# Altium schematic sheet DIGITAL_IO.SchDoc
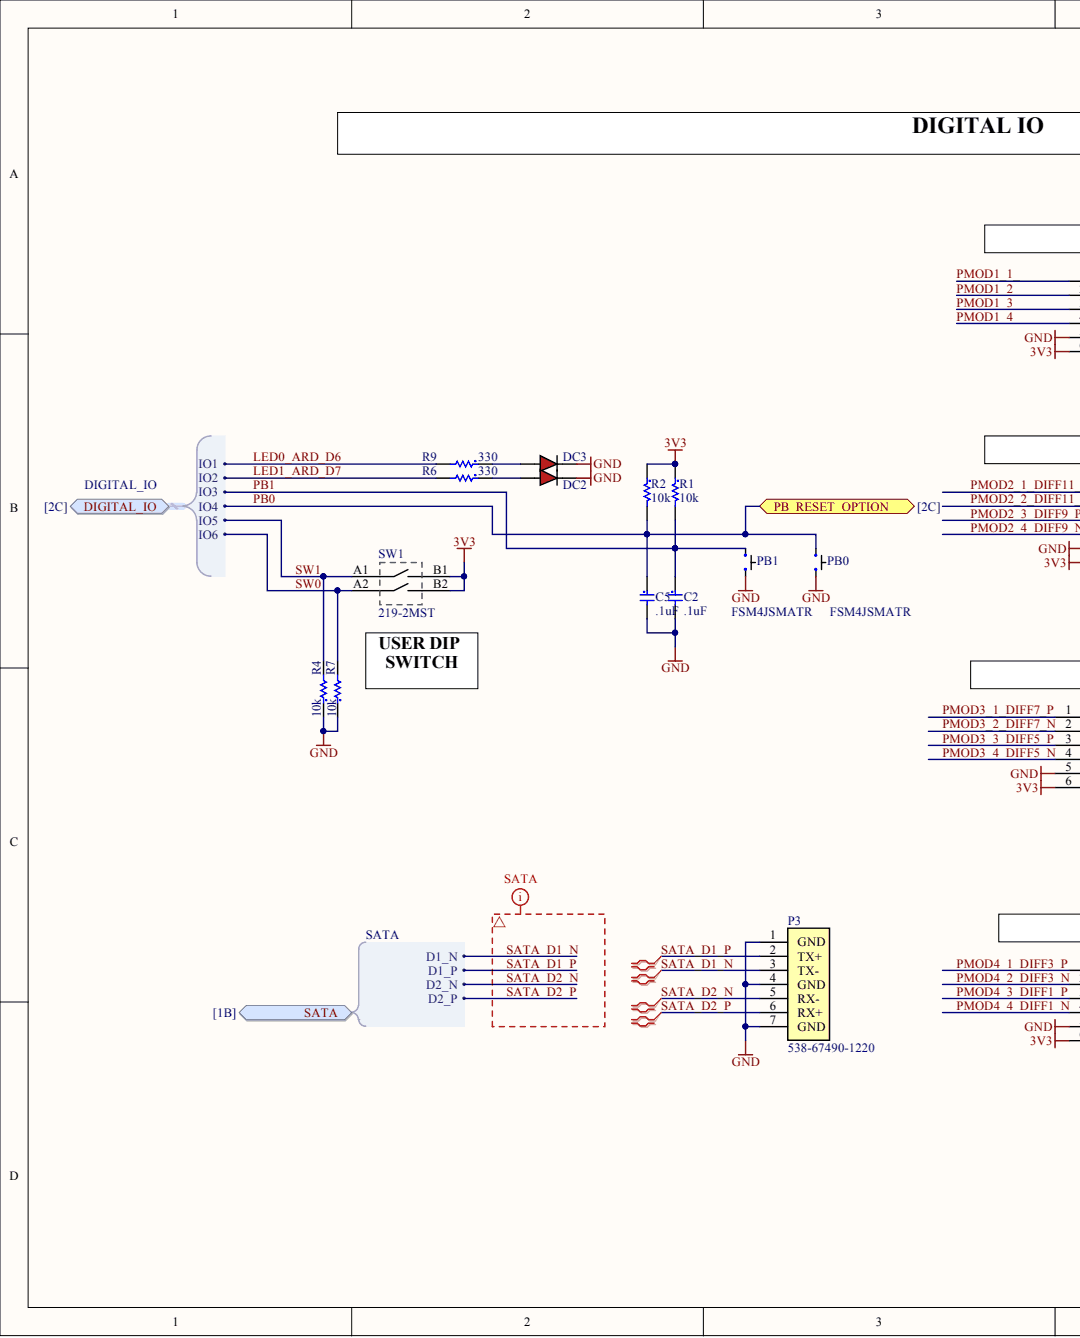
[diagram p0: DIGITAL_IO.SchDoc, left]
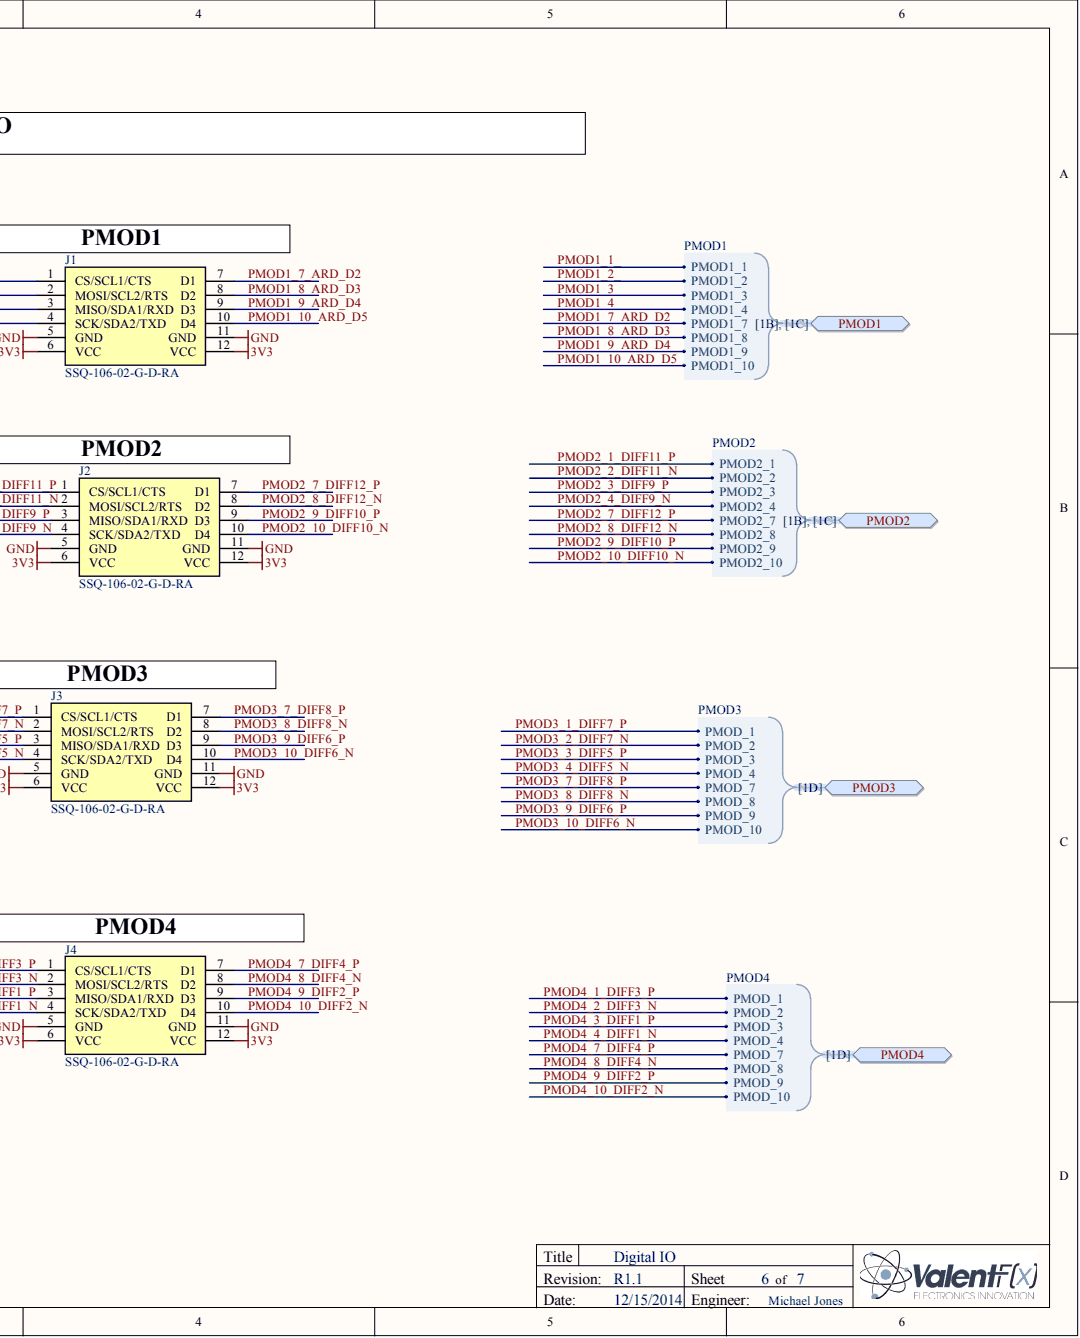
[diagram p1: DIGITAL_IO.SchDoc, right]

## Parts
C2  .1uF  [c0603]
C5  .1uF  [c0603]
DC2  598-8010-107F  [LED_0603 - RED]  (value not drawn)
DC3  598-8010-107F  [LED_0603 - RED]  (value not drawn)
J1  SSQ-106-02-G-D-RA  [PMOD HEADER - SSQ-106-02-G-D-RA]
J2  SSQ-106-02-G-D-RA  [PMOD HEADER - SSQ-106-02-G-D-RA]
J3  SSQ-106-02-G-D-RA  [PMOD HEADER - SSQ-106-02-G-D-RA]
J4  SSQ-106-02-G-D-RA  [PMOD HEADER - SSQ-106-02-G-D-RA]
P3  Supplier Part Number 1: 538-67490-1220  [SATA - 67490-3220]  (hidden comment: SATA - 67490-1220)
PB0  FSM4JSMATR  [FSM4JSMA]
PB1  FSM4JSMATR  [FSM4JSMA]
R1  10k  [R0603]
R2  10k  [R0603]
R4  10k  [R0603]
R6  330  [R0603]
R7  10k  [R0603]
R9  330  [R0603]
SW1  219-2MST  Switch Type: DIP  [DIPSW - 219-2MST]

## Ports
DIGITAL_IO, DIGITAL_IO.IO1, DIGITAL_IO.IO2, DIGITAL_IO.IO3, DIGITAL_IO.IO4, DIGITAL_IO.IO5, DIGITAL_IO.IO6, PB_RESET_OPTION, PMOD1, PMOD1.PMOD1_1, PMOD1.PMOD1_2, PMOD1.PMOD1_3, PMOD1.PMOD1_4, PMOD1.PMOD1_7, PMOD1.PMOD1_8, PMOD1.PMOD1_9, PMOD1.PMOD1_10, PMOD2, PMOD2.PMOD2_1, PMOD2.PMOD2_2, PMOD2.PMOD2_3, PMOD2.PMOD2_4, PMOD2.PMOD2_7, PMOD2.PMOD2_8, PMOD2.PMOD2_9, PMOD2.PMOD2_10, PMOD3, PMOD3.PMOD_1, PMOD3.PMOD_2, PMOD3.PMOD_3, PMOD3.PMOD_4, PMOD3.PMOD_7, PMOD3.PMOD_8, PMOD3.PMOD_9, PMOD3.PMOD_10, PMOD4, PMOD4.PMOD_1, PMOD4.PMOD_2, PMOD4.PMOD_3, PMOD4.PMOD_4, PMOD4.PMOD_7, PMOD4.PMOD_8, PMOD4.PMOD_9, PMOD4.PMOD_10, SATA, SATA.D1_N, SATA.D1_P, SATA.D2_N, SATA.D2_P

## Nets
3V3: J1.6(VCC) J1.12(VCC) J2.6(VCC) J2.12(VCC) J3.6(VCC) J3.12(VCC) J4.6(VCC) J4.12(VCC) R1.1 R2.1 SW1.B1 SW1.B2
GND: C2.2 C5.2 DC2.C DC3.C J1.5(GND) J1.11(GND) J2.5(GND) J2.11(GND) J3.5(GND) J3.11(GND) J4.5(GND) J4.11(GND) P3.1(GND) P3.4(GND) P3.7(GND) PB0.A PB1.A R4.1 R7.1
LED0_ARD_D6: R9.2 ; port DIGITAL_IO
LED1_ARD_D7: R6.2 ; port DIGITAL_IO
PB0: C5.1 PB0.B R2.2 ; port DIGITAL_IO, port PB_RESET_OPTION
PB1: C2.1 PB1.B R1.2 ; port DIGITAL_IO
PMOD1_1_: J1.1(CS/SCL1/CTS) ; port PMOD1
PMOD1_2_: J1.2(MOSI/SCL2/RTS) ; port PMOD1
PMOD1_3_: J1.3(MISO/SDA1/RXD) ; port PMOD1
PMOD1_4_: J1.4(SCK/SDA2/TXD) ; port PMOD1
PMOD1_7_ARD_D2: J1.7(D1) ; port PMOD1
PMOD1_8_ARD_D3: J1.8(D2) ; port PMOD1
PMOD1_9_ARD_D4: J1.9(D3) ; port PMOD1
PMOD1_10_ARD_D5: J1.10(D4) ; port PMOD1
PMOD2_1_DIFF11_P: J2.1(CS/SCL1/CTS) ; port PMOD2
PMOD2_2_DIFF11_N: J2.2(MOSI/SCL2/RTS) ; port PMOD2
PMOD2_3_DIFF9_P: J2.3(MISO/SDA1/RXD) ; port PMOD2
PMOD2_4_DIFF9_N: J2.4(SCK/SDA2/TXD) ; port PMOD2
PMOD2_7_DIFF12_P: J2.7(D1) ; port PMOD2
PMOD2_8_DIFF12_N: J2.8(D2) ; port PMOD2
PMOD2_9_DIFF10_P: J2.9(D3) ; port PMOD2
PMOD2_10_DIFF10_N: J2.10(D4) ; port PMOD2
PMOD3_1_DIFF7_P: J3.1(CS/SCL1/CTS) ; port PMOD3
PMOD3_2_DIFF7_N: J3.2(MOSI/SCL2/RTS) ; port PMOD3
PMOD3_3_DIFF5_P: J3.3(MISO/SDA1/RXD) ; port PMOD3
PMOD3_4_DIFF5_N: J3.4(SCK/SDA2/TXD) ; port PMOD3
PMOD3_7_DIFF8_P: J3.7(D1) ; port PMOD3
PMOD3_8_DIFF8_N: J3.8(D2) ; port PMOD3
PMOD3_9_DIFF6_P: J3.9(D3) ; port PMOD3
PMOD3_10_DIFF6_N: J3.10(D4) ; port PMOD3
PMOD4_1_DIFF3_P: J4.1(CS/SCL1/CTS) ; port PMOD4
PMOD4_2_DIFF3_N: J4.2(MOSI/SCL2/RTS) ; port PMOD4
PMOD4_3_DIFF1_P: J4.3(MISO/SDA1/RXD) ; port PMOD4
PMOD4_4_DIFF1_N: J4.4(SCK/SDA2/TXD) ; port PMOD4
PMOD4_7_DIFF4_P: J4.7(D1) ; port PMOD4
PMOD4_8_DIFF4_N: J4.8(D2) ; port PMOD4
PMOD4_9_DIFF2_P: J4.9(D3) ; port PMOD4
PMOD4_10_DIFF2_N: J4.10(D4) ; port PMOD4
SATA_D1_N: P3.3(TX-) ; port SATA
SATA_D1_P: P3.2(TX+) ; port SATA
SATA_D2_N: P3.5(RX-) ; port SATA
SATA_D2_P: P3.6(RX+) ; port SATA
SW0: R7.2 SW1.A2 ; port DIGITAL_IO
SW1: R4.2 SW1.A1 ; port DIGITAL_IO
NetDC2_A: DC2.A R6.1
NetDC3_A: DC3.A R9.1
N00165:

## Library data of the parts
C2 C5 = C0603 - CL10F104ZA8NNNC: CAP CER 0.1UF 25V Y5V 0603; Manufacturer: Samsung Electro-Mechanics America, Inc; Accept Alternative: Yes; Capacitance: 0.1µF; Temperature Coefficient: Y5V (F); Tolerance: -20%, +80%; Applications: General Purpose; Category: Capacitors; Component Kind: Standard; ComponentLink1Description: http://www.samsungsem.com/servlet/FileDownload?type=spec&file=CL10F104ZA8NNNC.pdf; ComponentLink1URL: http://www.samsungsem.com/servlet/FileDownload?type=spec&file=CL10F104ZA8NNNC.pdf; Features: -; Height - Seated (Max): -; Lead Spacing: -; Lead Style: -; Library Name: mCapacitors.SchLib; Manufacturer Part Number: CL10F104ZA8NNNC; Mounting Type: Surface Mount, MLCC; Operating Temperature: -30°C ~ 85°C; Package / Case: 0603 (1608 Metric); Packaging: Cut Tape (CT); Pricing 1: 1=0.1, 10=0.017, 25=0.012, 50=0.009, 100=0.0076, 250=0.00624, 500=0.00536, 1000=0.00417 (USD); Ratings: -; RoHS: RoHS Compliant; Size / Dimension: 0.063" L x 0.031" W (1.60mm x 0.80mm); Stock 1: 400907; Supplier 1: Digi-Key; Supplier Part Number 1: 1276-1011-1-ND; Thickness (Max): 0.035" (0.90mm); Value: .1uF; Voltage - Rated: 25V
DC2 DC3 = LED0603 - 598-8010-107F: LED ALINGAP RED CLEAR 0603 SMD; Manufacturer: Dialight; Accept Replacement: YES - Water Clear; Current - Test: 20mA; Lens Style/Size: Rectangle with Flat Top, 1.1mm x 0.8mm; Millicandela Rating: 40mcd; Viewing Angle: 140°; Voltage - Forward (Vf) Typ: 2.2V; Wavelength - Dominant: 635nm; Category: Optoelectronics; Color: Red; Component Kind: Standard; ComponentLink1Description: http://media.digikey.com/pdf/Data%20Sheets/Dialight%20PDFs/598_Series_0603_Pkg.pdf; ComponentLink1URL: http://media.digikey.com/pdf/Data%20Sheets/Dialight%20PDFs/598_Series_0603_Pkg.pdf; Height: 0.70mm; Lens Type: Clear; Library Name: mDiode.SchLib; Luminous Flux @ Current - Test: -; Manufacturer Part Number: 598-8010-107F; Mounting Type: Surface Mount; Package / Case: 0603 (1608 Metric); Packaging: Digi-Reel®; Pricing 1: 1=0.45, 10=0.33, 25=0.264, 100=0.1749, 250=0.1452, 500=0.1056, 1000=0.0825 (USD); RoHS: RoHS Compliant; Size / Dimension: 1.60mm L x 0.80mm W; Stock 1: 37578; Supplier 1: Digi-Key; Supplier Part Number 1: 350-2029-6-ND; Wavelength - Peak: -
J1-J4 = P-MOD - SSQ-106-02-G-D-RA: SAMTEC - SSQ-106-02-G-D-RA-005 - 2MM SOCKET STRIPS; Component Kind: Standard; Library Name: mConnector.SchLib; Manufacturer: SAMTEC; Manufacturer Part Number: SSQ-106-02-G-D-RA; Pricing 1: 1=1.58, 100=1.58, 1000=1.58 (USD); Stock 1: 0; Supplier 1: Samtec; Supplier Part Number 1: SSQ-106-02-G-D-RA; Value: SSQ-106-02-G-D-RA
P3 = SATA - 67490-1220: SATA Data - 67490-1220; Manufacturer: Molex; 1Notes: looks more standard need, different footprint; Gender: Male; Number of Positions / Contacts: 7; Pitch: 1.27 mm; Category: I/O Connectors; Component Kind: Standard; ComponentLink1Description: http://www.molex.com/webdocs/datasheets/pdf/en-us/0674901220_PCB_HEADERS.pdf; ComponentLink1URL: http://www.molex.com/webdocs/datasheets/pdf/en-us/0674901220_PCB_HEADERS.pdf; Library Name: mConnector.SchLib; Manufacturer Part Number: 67490-1220; Minimum Order Quantity: 1; Mounting Style: SMD/SMT; Packaging: Tray; Pricing 1: 1=1.28, 10=1.07, 100=0.792, 500=0.640, 1000=0.572, 2000=0.525 (AUD); Product: SATA Connectors; Product Category: 1.27mm Connectors; Reeling: False; RoHS: RoHS Compliant; RoHS - Mouser: Y; Standard Pack Qty: 2880; Stock 1: 475; Supplier 1: Mouser; Termination Style: Solder Terminal
PB0 PB1 = FSM4JSMATR: SWITCH TACTILE SPST-NO 0.05A 12V; Manufacturer: TE Connectivity; Actuator Height off PCB, from Pins: 5.00mm; Actuator Material: Polyphenylene Sulfide (PPS); Actuator Orientation: Top Actuated; Actuator Type: Standard; Contact Rating @ Voltage: 0.05A @ 12VDC; Illumination: Non-Illuminated; Mechanical Life: 100,000 Cycles; Operating Force: 160gf; Outline: 6.00mm x 6.00mm; Switch Function: Off-Mom; Switch Travel: 0.25mm; Category: Switches; Circuit: SPST-NO; Component Kind: Standard; ComponentLink1Description: http://www.te.com/commerce/DocumentDelivery/DDEController?Action=srchrtrv&DocNm=2-1437565-7&DocType=Customer+Drawing&DocLang=English; ComponentLink1URL: http://www.te.com/commerce/DocumentDelivery/DDEController?Action=srchrtrv&DocNm=2-1437565-7&DocType=Customer+Drawing&DocLang=English; Features: -; Illumination Type, Color: -; Illumination Voltage (Nominal): -; Ingress Protection: -; Library Name: mElectroMechanical.SchLib; Manufacturer Part Number: FSM4JSMA; Mounting Type: Surface Mount; Operating Temperature: -35°C ~ 85°C; Packaging: Bulk; Pricing 1: 1=0.26, 10=0.246, 25=0.2096, 50=0.1834, 100=0.1703, 250=0.15064, 500=0.1441, 1000=0.1179, 5000=0.09825 (USD); RoHS: RoHS Compliant; Stock 1: 13038; Supplier 1: Digi-Key; Supplier Part Number 1: 450-1129-ND; Termination Style: Gull Wing; Value: FSM4JSMATR
R1 R2 R4 R7 = R0603 - CRCW060310K0FKEA: 10k Thick Film Resistors - SMD 1/10watt 10Kohms 1%; Manufacturer: Vishay Dale; Power (Watts): 0.1W, 1/10W; Temperature Coefficient: ±100ppm/°C; Category: Resistors; Component Kind: Standard; ComponentLink1Description: http://www.vishay.com/doc?20035; ComponentLink1URL: http://www.vishay.com/doc?20035; Composition: Thick Film; Features: -; Height: 0.020" (0.50mm); Library Name: mResistors.SchLib; Manufacturer Part Number: CRCW060310K0FKEA; Number of Terminations: 2; Package / Case: 0603 (1608 Metric); Packaging: Cut Tape (CT); Pricing 1: 10=0.081, 50=0.0436, 200=0.0251, 1000=0.01711 (USD); Resistance (Ohms): 10K; RoHS: RoHS Compliant; Size / Dimension: 0.061" L x 0.033" W (1.55mm x 0.85mm); Stock 1: 997856; Supplier 1: Digi-Key; Supplier Part Number 1: 541-10.0KHCT-ND; Tolerance: ±1%; Value: 10k
R6 R9 = R0603 - ERJ-3GEYJ331V: Thick Film Resistors - SMD 0603 330ohms 5% Tol; Manufacturer: Panasonic; Power Rating: 100 mW; Temperature Coefficient: 200 PPM / C; Voltage Rating: 75 V; Case Code - in: 0603; Case Code - mm: 1608; Category: Thick Film Resistors - SMD; Component Kind: Standard; ComponentLink1Description: http://www.mouser.com/ds/2/315/AOA0000CE1-37422.pdf; ComponentLink1URL: http://www.mouser.com/ds/2/315/AOA0000CE1-37422.pdf; Dimensions: 0.8 mm W x 1.6 mm L x 0.45 mm H; Library Name: mResistors.SchLib; Manufacturer Part Number: ERJ-3GEYJ331V; Minimum Order Quantity: 1; Operating Temperature Range: - 55 C to + 155 C; Package / Case: 0603 (1608 metric); Packaging: Reel; Pricing 1: 1=0.108, 10=0.012, 100=0.005, 1000=0.004, 5000=0.003 (AUD); Product: Thick Film Resistors SMD; Reeling: True; Resistance: 330 Ohms; RoHS: RoHS Compliant By Exemption; RoHS - Mouser: E; Series: ERJ; Standard Pack Qty: 5000; Stock 1: 131470; Supplier 1: Mouser; Supplier Part Number 1: 667-ERJ-3GEYJ331V; Termination Style: SMD/SMT; Tolerance: 5 %; Value: 330
SW1 = DIPSW - 219-2MST: DIP Switches / SIP Switches SPST 2 switch sections; Manufacturer: CTS Electronic Components; Number of Positions: 2; Category: DIP Switches / SIP Switches; Component Kind: Standard; ComponentLink1Description: http://www.ctscorp.com/components/Datasheets/219.pdf; ComponentLink1URL: http://www.ctscorp.com/components/Datasheets/219.pdf; Library Name: mElectroMechanical.SchLib; Manufacturer Part Number: 219-2MST; Minimum Order Quantity: 1; Operating Temperature Range: - 55 C to + 85 C; Packaging: Tube; Pricing 1: 1=0.580, 10=0.530, 100=0.480, 500=0.350, 1000=0.300, 5000=0.270 (USD); Product Category: DIP Switches; Reeling: False; RoHS: RoHS Compliant; RoHS - Mouser: Y; Stock 1: 3237; Supplier 1: Mouser; Supplier Part Number 1: 774-2192MST; Terminal Pitch: 2.54 mm; Termination Style: SMD/SMT; Value: 219-2MST
